# Altium schematic sheet Ethernet.SchDoc
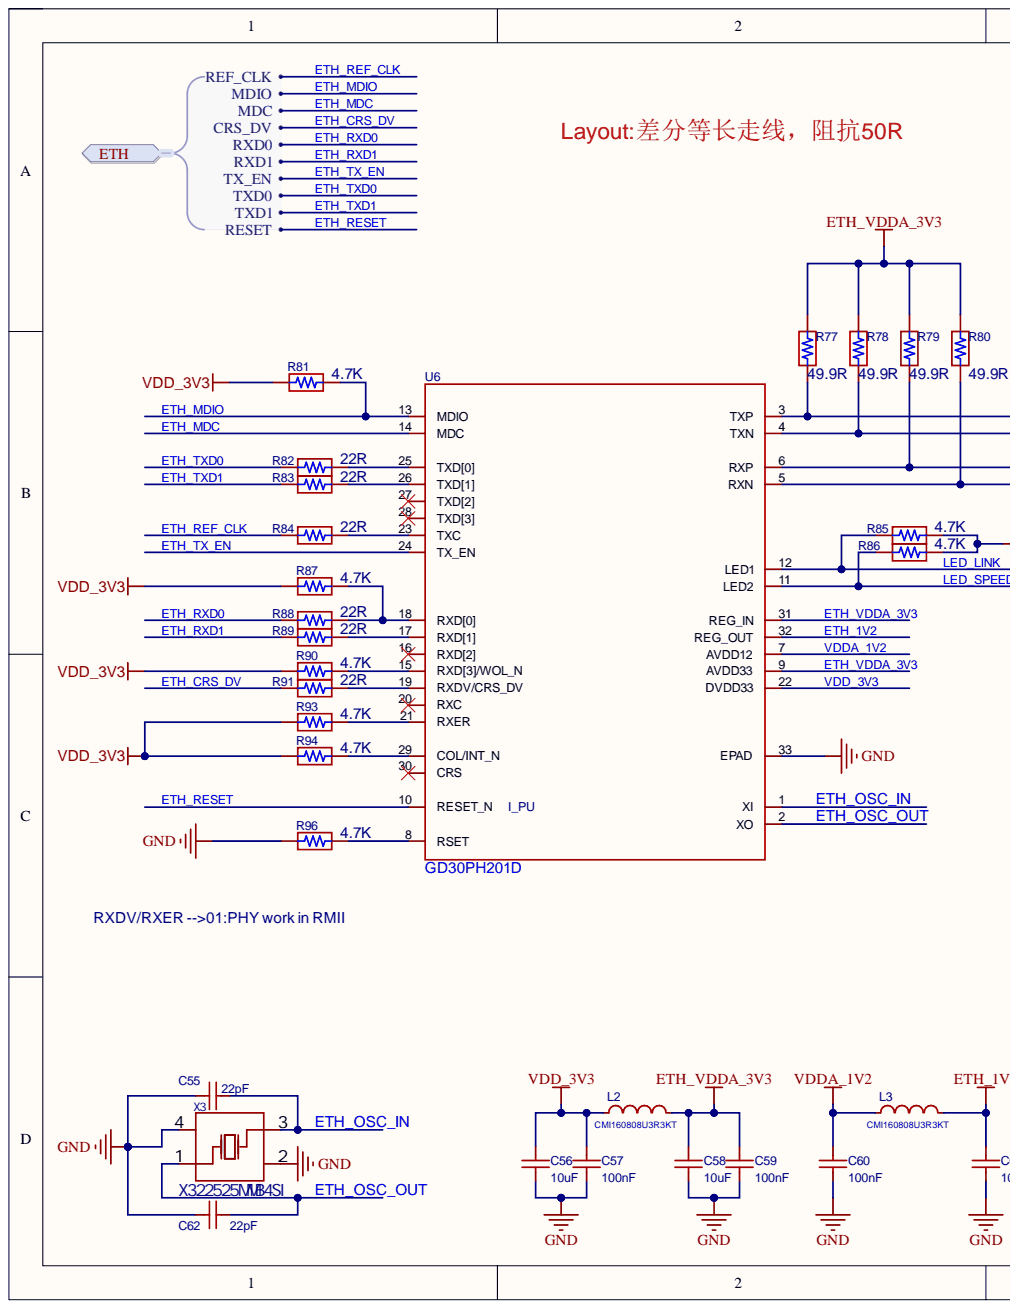
[diagram p0: Ethernet.SchDoc, left]
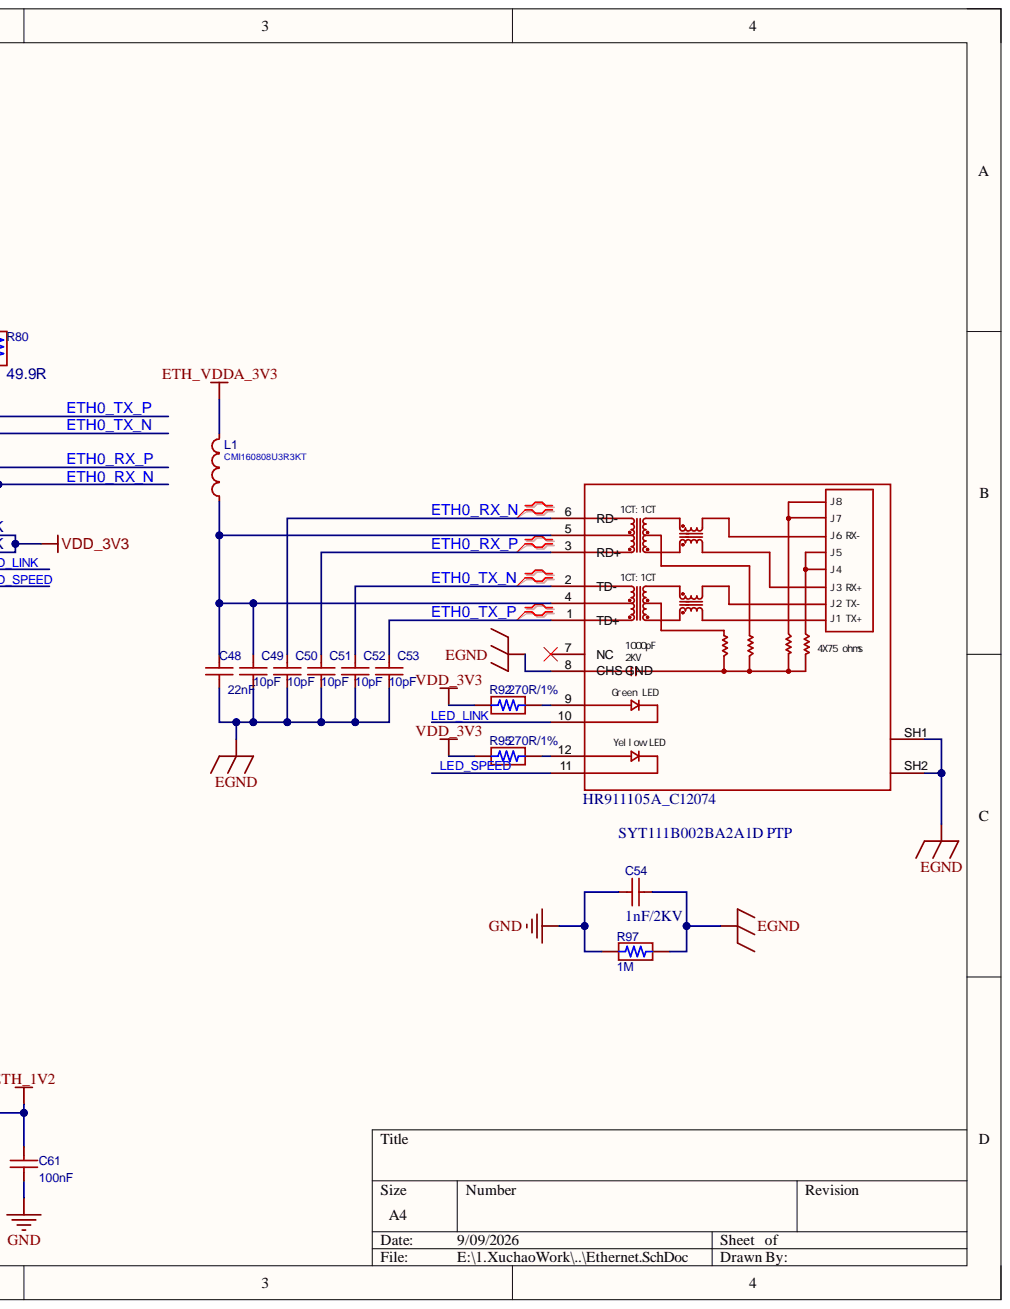
[diagram p1: Ethernet.SchDoc, right]

## Parts
C48  22nF  [C0402]
C49  10pF  [C0402]
C50  10pF  [C0402]
C51  10pF  [C0402]
C52  10pF  [C0402]
C53  10pF  [C0402]
C54  1nF/2KV  [C1206]
C55  22pF  [C0603]
C56  10uF  [C0402]
C57  100nF  [C0603]
C58  10uF  [C0402]
C59  100nF  [C0402]
C60  100nF  [C0402]
C61  100nF  [C0402]
C62  22pF  [C0603]
J1  HR911105A_C12074  [RJ45-TH_HR911105A]
L1  CMI160808U3R3KT  [L0603]
L2  CMI160808U3R3KT  [L0603]
L3  CMI160808U3R3KT  [L0603]
R77  49.9R  [R0402]
R78  49.9R  [R0402]
R79  49.9R  [R0402]
R80  49.9R  [R0402]
R81  4.7K  [R0402]
R82  22R  [R0402]
R83  22R  [R0402]
R84  22R  [R0402]
R85  4.7K  [R0402]
R86  4.7K  [R0402]
R87  4.7K  [R0402]
R88  22R  [R0402]
R89  22R  [R0402]
R90  4.7K  [R0402]
R91  22R  [R0402]
R92  270R/1%  [R0402]
R93  4.7K  [R0402]
R94  4.7K  [R0402]
R95  270R/1%  [R0402]
R96  4.7K  [R0402]
R97  1M  [R1206]
U6  GD30PH201D  [QFN-32_L5.0-W5.0-P0.50-BL-EP3.4]
X3  X322525MMB4SI  [OSC 3225-4P]

## Ports
ETH, ETH.CRS_DV, ETH.MDC, ETH.MDIO, ETH.REF_CLK, ETH.RESET, ETH.RXD0, ETH.RXD1, ETH.TX_EN, ETH.TXD0, ETH.TXD1

## Nets
EGND: C48.1 C49.1 C50.1 C51.1 C52.1 C53.1 C54.2 J1.8(CHS_GND) J1.SH1 J1.SH2 R97.1
GND: C54.1 C55.2 C56.1 C57.1 C58.1 C59.1 C60.1 C61.1 C62.2 R96.1 R97.2 U6.33(EPAD) X3.2 X3.4
ETH0_RX_N: C50.2 J1.6(RD-) R80.2 U6.5(RXN)
ETH0_RX_P: C51.2 J1.3(RD+) R79.2 U6.6(RXP)
ETH0_TX_N: C52.2 J1.2(TD-) R78.2 U6.4(TXN)
ETH0_TX_P: C53.2 J1.1(TD+) R77.2 U6.3(TXP)
ETH_1V2: C61.2 L3.2 U6.32(REG_OUT)
ETH_CRS_DV: R91.1 ; port ETH
ETH_MDC: U6.14(MDC) ; port ETH
ETH_MDIO: R81.2 U6.13(MDIO) ; port ETH
ETH_OSC_IN: C55.1 U6.1(XI) X3.3
ETH_OSC_OUT: C62.1 U6.2(XO) X3.1
ETH_REF_CLK: R84.1 ; port ETH
ETH_RESET: U6.10(RESET_N) ; port ETH
ETH_RXD0: R88.1 ; port ETH
ETH_RXD1: R89.1 ; port ETH
ETH_TX_EN: U6.24(TX_EN) ; port ETH
ETH_TXD0: R82.1 ; port ETH
ETH_TXD1: R83.1 ; port ETH
ETH_VDDA_3V3: C58.2 C59.2 L1.2 L2.2 R77.1 R78.1 R79.1 R80.1 U6.9(AVDD33) U6.31(REG_IN)
LED_LINK: J1.10 R85.2 U6.12(LED1)
LED_SPEED: J1.11 R86.2 U6.11(LED2)
VDD_3V3: C56.2 C57.2 L2.1 R81.1 R85.1 R86.1 R87.1 R90.1 R92.1 R93.1 R94.1 R95.1 U6.22(DVDD33)
VDDA_1V2: C60.2 L3.1 U6.7(AVDD12)
NetC48_2: C48.2 C49.2 J1.4 J1.5 L1.1
NetJ1_7: J1.7(NC)
NetJ1_9: J1.9 R92.2
NetJ1_12: J1.12 R95.2
NetR82_2: R82.2 U6.25(TXD[0])
NetR83_2: R83.2 U6.26(TXD[1])
NetR84_2: R84.2 U6.23(TXC)
NetR87_2: R87.2 R88.2 U6.18(RXD[0])
NetR89_2: R89.2 U6.17(RXD[1])
NetR90_2: R90.2 U6.15(RXD[3]/WOL_N)
NetR91_2: R91.2 U6.19(RXDV/CRS_DV)
NetR93_2: R93.2 U6.21(RXER)
NetR94_2: R94.2 U6.29(COL/INT_N)
NetR96_2: R96.2 U6.8(RSET)
NetU6_16: U6.16(RXD[2])
NetU6_20: U6.20(RXC)
NetU6_27: U6.27(TXD[2])
NetU6_28: U6.28(TXD[3])
NetU6_30: U6.30(CRS)

## Library data of the parts
C48-C62 = 0SMD_CAP: Symbol: CL10A106KP8NNNC; Component Kind: Standard; Library Name: CYKJ_LibPkg.IntLib
J1 = HR911105A_C12074: RJ45插座，单口100M，90度直插，带LED，商业级，汉仁; Component Kind: Standard; Symbol: HR911105A_C12074; Library Name: CYKJ_LibPkg.IntLib
L1-L3 = 0SMD_Inductor: Component Kind: Standard; Symbol: CMI160808U3R3KT; Library Name: CYKJ_LibPkg.IntLib
R77-R91 R93 R94 R96 = 0SMD_RES: Component Kind: Standard; Library Name: CYKJ_LibPkg.IntLib
R92 R95 R97 = Resisteors: RES_SMD; Component Kind: Standard; Library Name: CYKJ_library.SchLib
U6 = IC_ETH_GD30PH201D: 以太网收发器,单口工业以太网百兆 PHY; Symbol: YT8512H; Component Kind: Standard; Library Name: CYKJ_library.SchLib
X3 = OSC_X322525MMB4SI: OSC_3225; Manufacturer: YXC(扬兴晶振); Add into BOM: yes; Crystal Type: 贴片无源晶振; Frequency Stability: ±30ppm; Frequency Tolerance: ±10ppm; Load Capacitance: 10pF; Component Kind: Standard; Convert to PCB: yes; Datasheet: https://atta.szlcsc.com/upload/public/pdf/source/20210329/C112971_1F611BDC486E193C1706F6D8EB5AA189.pdf; Device: X322525MMB4SI; JLCPCB Part Class: 扩展库; LCSC Part Name: 25MHz ±10ppm 10pF YSX321SL; Manufacturer Part: X322525MMB4SI; Operating Temperature: -40℃~+85℃; Supplier: LCSC; Supplier Footprint: SMD3225-4P; Supplier Part: C70582; Symbol: X322525MMB4SI; Library Name: CYKJ_LibPkg.IntLib
